# Altium schematic sheet TEMPLATE_Circuit.SchDoc(TEMPLATE_Circuit)
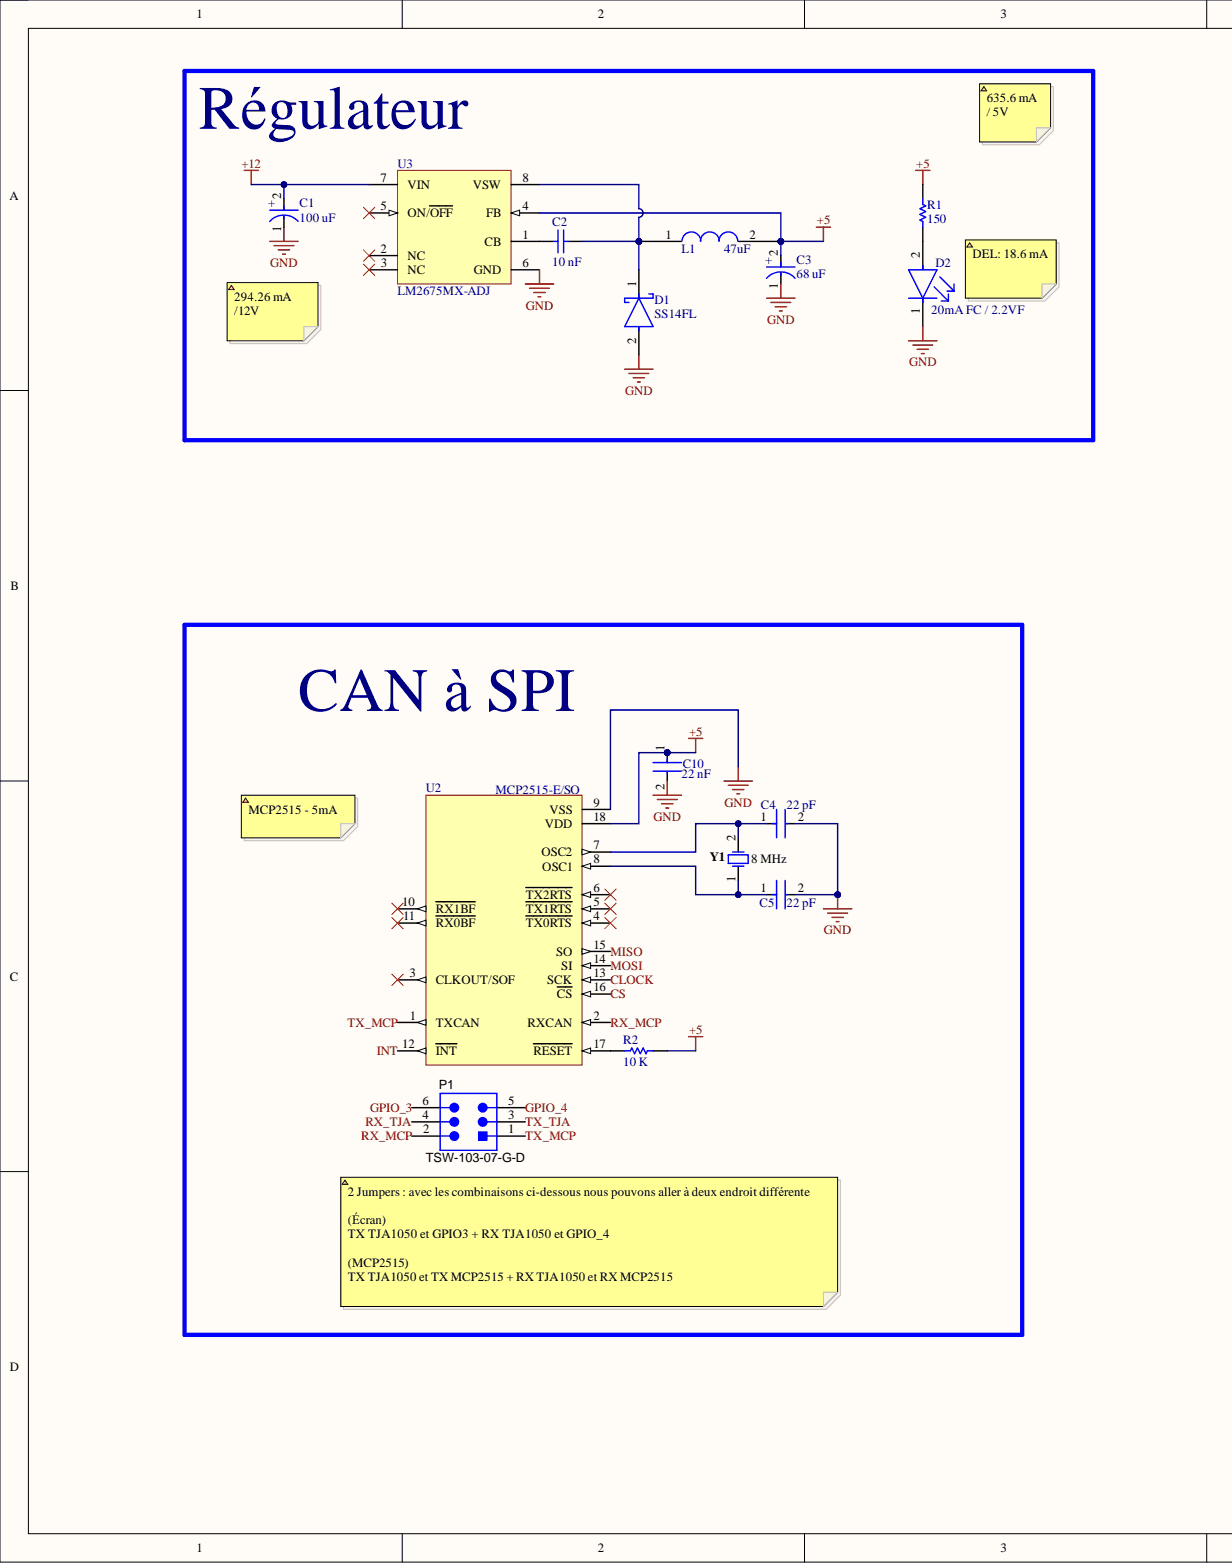
[diagram p0: TEMPLATE_Circuit.SchDoc, left]
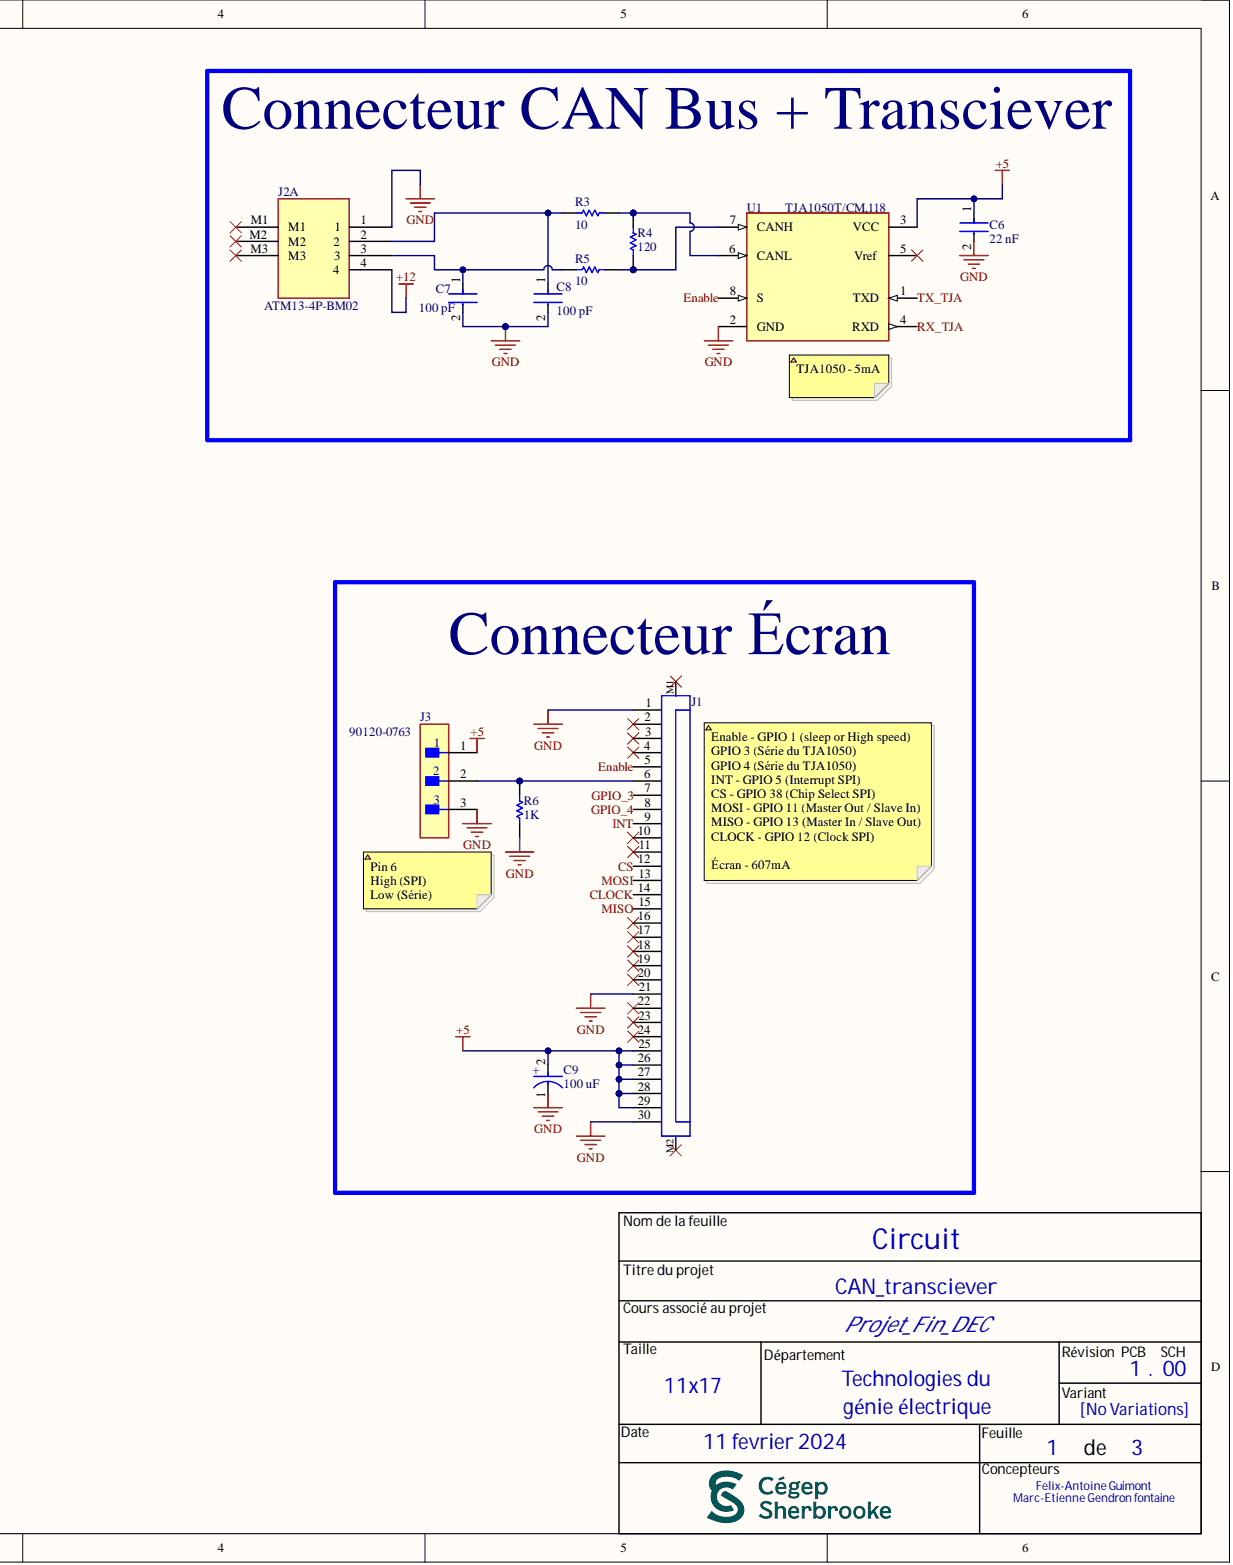
[diagram p1: TEMPLATE_Circuit.SchDoc, right]

## Parts
C1  100 uF  [FP-FKF-MFG]
C2  10 nF  [CAPC1608X90X35ML10T15]
C3  68 uF  [FP-OS-CON-SMT-F12-MFG]
C4  22 pF  [FP-C0805C-DN-MFG]
C5  22 pF  [FP-C0805C-DN-MFG]
C6  22 nF  [FP-C0805C-DN-MFG]
C7  100 pF  [FP-CC0805-0_1-0_7-IPC_A]
C8  100 pF  [FP-CC0805-0_1-0_7-IPC_A]
C9  100 uF  [FP-FKF-MFG]
C10  22 nF  [FP-C0805C-DN-MFG]
D1  SS14FL  [FP-425AD-MFG]
D2  20mA FC / 2.2VF  Forward Current: 20mA  [FP-SML-210-MFG]
J1  68613014422  [68613014422]  (value not drawn)
J2  ATM13-4P-BM02  [ATM134PBM02]  (part A)
J3  90120-0763  [FP-90120-0763-MFG]
L1  47uF  [NPI31W470MTRF]
P1  TSW-103-07-G-D  [SMTC-TSW-103-07-G-D_V]
R1  150  [RESC2013X60X40NL20T25]
R2  10 K  [RESC2013X60X35NL10T20]
R3  10  [RESC2013X50X30NL20T20]
R4  120  [RESC2013X60X40ML20T25]
R5  10  [RESC2013X50X30NL20T20]
R6  1K  [RESC2013X60X35ML10T20]
U1  TJA1050T/CM,118  [FP-SOT96-1-IPC_A]
U2  MCP2515-E/SO  [SOIC-SO18_M]
U3  LM2675MX-ADJ  [D0008A_N]
Y1  8 MHz  [FOX-HC49SDLF_V]

## Nets
+5: C3.2 C6.1 C9.2 C10.1 J1.25 J1.26 J1.27 J1.28 J1.29 J3.1 L1.2 R1.2 R2.2 U1.3(VCC) U2.18(VDD) U3.4(FB)
+12: C1.2 J2.4 U3.7(VIN)
GND: C1.1 C3.1 C4.2 C5.2 C6.2 C7.2 C8.2 C9.1 C10.2 D1.2 D2.1 J1.1 J1.21 J1.30 J2.1 J3.3 R6.1 U1.2(GND) U2.9(VSS) U3.6(GND)
CLOCK: J1.14 U2.13(SCK)
CS: J1.12 U2.16(CS)
Enable: J1.5 U1.8(S)
GPIO_3: J1.7 P1.6
GPIO_4: J1.8 P1.5
INT: J1.9 U2.12(INT)
MISO: J1.15 U2.15(SO)
MOSI: J1.13 U2.14(SI)
RX_MCP: P1.2 U2.2(RXCAN)
RX_TJA: P1.4 U1.4(RXD)
TX_MCP: P1.1 U2.1(TXCAN)
TX_TJA: P1.3 U1.1(TXD)
NetC2_1: C2.1 U3.1(CB)
NetC2_2: C2.2 D1.1 L1.1 U3.8(VSW)
NetC4_1: C4.1 U2.7(OSC2) Y1.2
NetC5_1: C5.1 U2.8(OSC1) Y1.1
NetC7_1: C7.1 J2.3 R5.1
NetC8_1: C8.1 J2.2 R3.1
NetD2_2: D2.2 R1.1
NetJ1_6: J1.6 J3.2 R6.2
NetR2_1: R2.1 U2.17(RESET)
NetR3_2: R3.2 R4.2 U1.6(CANL)
NetR4_1: R4.1 R5.2 U1.7(CANH)

Not connected: J1 2 3 4 10 11 16 17 18 19 20 22 23 24 M1 M2; J2 M1 M2 M3; U1 5(Vref); U2 3(CLKOUT/SOF) 4(TX0RTS) 5(TX1RTS) 6(TX2RTS) 10(RX1BF) 11(RX0BF); U3 2(NC) 3(NC) 5(ON/OFF)

## Library data of the parts
C1 C9 = CMP-2000-06208-3: CAP ALUM 100UF 20% 50V SMD; Dielectric Material: Aluminium; ESR (Equivalent Series Resistance): 340mR; Leakage Current: 3uA; Life (Hours): 2000Hour; Ripple Current: 350mA; Capacitance: 100uF; Case/Package: Radial; Component Kind: Standard; Construction: Cylindrical; Contact Plating: Tin; Diameter: 8mm; Height: 10.2mm; Height - Seated (Max): 0.402inch; Impedance: 340mR; Lead Free: Lead Free; Length: 10.2mm; Library Name: Altium Content Vault; Material: Aluminium; Max Operating Temperature: 105°C; Min Operating Temperature: -55°C; Mount: Surface Mount; Number of Pins: 2; Package Quantity: 1; Packaging: Tape and Reel; Radiation Hardening: No; REACH SVHC: No SVHC; Ripple Current (AC): 350mA; RoHS Compliant: Yes; Series: FK; Termination: SMD/SMT; Tolerance: 20%; Voltage: 50V; Voltage Rating: 50V; Voltage Rating (DC): 50V; Width: 10mm
C2 = CMP-2100-03642-1: Chip Capacitor, 10 nF, +/- 10%, 50 V, -55 to 125 degC, 0603 (1608 Metric), RoHS, Tape and Reel; Manufacturer: Murata; Capacitance: 10nF; Dielectric: X7R; Dielectric Material: Ceramic; Insulation Resistance: 10GR; Voltage: 50V; Case Code (Imperial): 0603; Case Code (Metric): 1608; Case/Package: 0603; Component Kind: Standard; Datasheet URL: http://search.murata.co.jp/Ceramy/image/img/A01X/G101/ENG/GRM188R71H103KA01-01.pdf; Datasheet Version: 42401.0; Depth: 0.8mm; Height: 0.8mm; Lead Free: LeadFree; Length: 1.6mm; Library Name: Altium Content Vault; Manufacturer URL: http://www.murata.com/; Material: Ceramic; Max Operating Temperature: 125degC; Min Operating Temperature: -55degC; Mount: SurfaceMount; Number of Pins: 2; Package Description: 2-Pin Surface Mount Device, Body 1.6 x 0.8 mm; Package Quantity: 1; Package Reference: 0603; Packaging: TapeandReel; REACH SVHC: Unknown; RoHSCompliant: True; Termination: SMD/SMT; Thickness: 0.035inch; Tolerance: 10%; Voltage Rating: 50V; Voltage Rating (DC): 50V; Width: 0.031inch
C3 = CMP-05428-000631-1: Conductive Polymer Aluminum Solid Capacitors (OS-CON) 68uF ±20% 50V RADIAL SMT 10x12.6mm; Dissipation Factor: 12%; ESR (Equivalent Series Resistance): 20mR; Leakage Current: 680uA; Life (Hours): 5000Hour; Polarity: Polar; Ripple Current: 4.3A; Capacitance: 68uF; Case/Package: Radial; Component Kind: Standard; Construction: Cylindrical; Diameter: 10mm; Height: 12.6mm; Height - Seated (Max): 0.5inch; Lead Free: Lead Free; Length: 12.6mm; Library Name: Altium Content Vault; Max Operating Temperature: 105°C; Min Operating Temperature: -55°C; Mount: Surface Mount; Number of Pins: 12; Package Quantity: 400; Packaging: Tape and Reel; REACH SVHC: No SVHC; Ripple Current (AC): 4.3A; RoHS Compliant: Yes; Series: OS-CON™, SVPF; Termination: SMD/SMT; Tolerance: 20%; Voltage: 50V; Voltage Rating: 50V; Voltage Rating (DC): 50V
C4 C5 = CMP-2007-00048-2: Capacitor; Ceramic; Cap; 22.0pF; Tol 5%; SMT; Vol-Rtg 50V; C0G; Tape and Reel; Manufacturer: KEMET; Manufacturer Part Number: C0805C220J5GACTU; Temperature Coefficient: 30ppm/°C; Tolerance: 0.05; Automotive: No; Capacitance: 22 pF; Category: Cap; Component Kind: Standard; ComponentLink2Description: Datasheet; ComponentLink2URL: https://content.kemet.com/datasheets/KEM_C1003_C0G_SMD.pdf; Device Class L1: Passive Components; Device Class L2: Capacitors; Device Class L3: Ceramic Capacitors; Digikey Description: Capacitor; Ceramic; Cap; 22.0pF; Tol 5%; SMT; Vol-Rtg 50V; C0G; Tape and Reel; Height: 0.88mm; Ipc Land Pattern Name: CAPC200125X78; Lead Free: Yes; Library Name: Altium Content Vault; Material: Ceramic; Mouser Description: Multilayer Ceramic Capacitors MLCC - SMD/SMT 50volts 22pF C0G 5%; Octopart: https://octopart.com/c0805c220j5gactu-kemet-145470; Package: C0805C-DN; RoHS: TRUE; Temperature: -55°C to +125°C; Temperature Characteristic: C0G; Temperature Range High: +125°C; Temperature Range Low: -55°C; Value: 22 pF; Voltage Rating: 50 V
C6 C10 = CMP-2007-00338-2: CAP CER 0.022UF 50V X7R 0805; Manufacturer: KEMET; Manufacturer Part Number: C0805C223K5RACTU; Capacitance: 22,000 pF; Material: Ceramic; Temperature Coefficient: 30ppm/°C; Tolerance: 0.1; Value: 22,000 pF; Automotive: No; Category: Cap; Component Kind: Standard; ComponentLink2Description: Datasheet; ComponentLink2URL: https://content.kemet.com/datasheets/KEM_C1003_C0G_SMD.pdf; Device Class L1: Passive Components; Device Class L2: Capacitors; Device Class L3: Ceramic Capacitors; Digikey Description: CAP CER 0.022UF 50V X7R 0805; Height: 0.88mm; Ipc Land Pattern Name: CAPC200125X78; Lead Free: Yes; Library Name: Altium Content Vault; Mouser Description: Capacitor; Ceramic; Cap; .022uF; Tol 10%; SMT; Vol-Rtg 50V; X7R; Tape and Reel; Octopart: https://octopart.com/c0805c223k5ractu-kemet-145968; Package: C0805C-DN; RoHS: TRUE; Temperature: -55°C to +125°C; Temperature Characteristic: X7R; Temperature Range High: +125°C; Temperature Range Low: -55°C; Voltage Rating: 50 V
C7 C8 = CMP-03422-001508-1: CAP CER 100PF 50V C0G/NPO 0805; Dielectric: C0G; Dielectric Material: Ceramic; Insulation Resistance: 100GR; Temperature Coefficient: 30ppm/°C; Tolerance: 5%; Capacitance: 100pF; Case Code (Imperial): 0805; Case Code (Metric): 2012; Case/Package: 0805; Component Kind: Standard; Depth: 1.25mm; Height: 0.6mm; Lead Free: Lead Free; Length: 2mm; Library Name: Altium Content Vault; Max Operating Temperature: 125°C; Min Operating Temperature: -55°C; Mount: Surface Mount; Number of Pins: 2; Package Quantity: 1; Packaging: Tape and Reel; Radiation Hardening: No; REACH SVHC: No SVHC; Resistor Type: Ceramic; RoHS Compliant: Yes; Series: CC; Termination: SMD/SMT; Thickness: 0.024inch; Voltage: 50V; Voltage Rating: 50V; Voltage Rating (DC): 50V; Width: 1.25mm
D1 = CMP-07162-000013-1: DIODE SCHOTTKY 40V 1A SOD123F; Manufacturer: ON Semiconductor; Capacitance: 60pF; Max Junction Temp: +125°C; Max Surge Forward Current: 40A; Peak Reverse Current: 30uA; Value: 60pF; Automotive: No; Category: Diode; Component Kind: Standard; ComponentLink2Description: Datasheet; ComponentLink2URL: https://www.onsemi.com/pub/Collateral/SS14FL-D.PDF; Device Class L1: Discrete Semiconductors; Device Class L2: Diodes; Device Class L3: Schottky Diodes; Digikey Description: DIODE SCHOTTKY 40V 1A SOD123F; Forward Current: 1A; Height: 1.08mm; Lead Free: Yes; Library Name: Altium Content Vault; Manufacturer Part Number: SS14FL; Max Repetitive Reverse Voltage: 40V; Mouser Description: SS14FL Series 40 V 1 A Surface Mount Schottky Barrier Rectifier - SOD-123F; Octopart: https://octopart.com/ss14fl-on+semiconductor-84331601; Package: 425AD; Reverse Voltage: 40V; RoHS: TRUE; Temperature: -55°C to +125°C; Temperature Range High: +125°C; Temperature Range Low: -55°C
D2 = CMP-08952-000013-1: LED GREEN CLEAR 0805 SMD; Manufacturer: ROHM; Manufacturer Part Number: SML-210MTT86; Forward Voltage: 2.2V; Led Orientation: Top View; Luminous Intensity: 16mcd; Peak Emmision Wavelength: 573nm; Power Dissipation: 70mW; Reverse Voltage: 4V; Wavelength: 570nm; Automotive: No; Category: Diode; Colour: Green; Component Kind: Standard; ComponentLink2Description: Datasheet; ComponentLink2URL: https://www.mouser.in/ds/2/348/sml-21-313092.pdf; Device Class L1: Optoelectronics; Device Class L2: LEDs; Device Class L3: unset; Digikey Description: LED GREEN CLEAR 0805 SMD; Height: 0.90mm; Ipc Land Pattern Name: DIOCP200125X25; Lead Free: Yes; Library Name: Altium Content Vault; Mouser Description: Standard LEDs - SMD GREEN SMD TRANS LENS; Octopart: https://octopart.com/sml-210mtt86-rohm-550711; Package: SML-210; RoHS: TRUE; Temperature: -30°C to +85°C; Temperature Range High: +85°C; Temperature Range Low: -30°C
J1 = CMP-1502-01467-2: NexarPartId: 22802282; Actuator Material: Polyphenylene; Contact Resistance: 20mΩ; Current Rating: 1A; Gender: Receptacle; Insulation Resistance: 100MΩ; Number of Contacts: 30; Operating Supply Voltage: 125V; Pitch: 1mm; Component Kind: Standard; Contact Material: Bronze, Tin; Contact Plating: Tin; Flammability Rating: UL94 V-0; Housing Material: Polyphenylene; Lead Pitch: 1mm; Length: 37mm; Library Name: Altium Content Vault; Max Operating Temperature: 85°C; Min Operating Temperature: -25°C; Mount: Surface Mount; Number of Pins: 30; Number of Positions: 30; Number of Rows: 1; Orientation: Horizontal; Packaging: Tape and Reel; REACH SVHC: Unknown; RoHS: Compliant; Termination: Solder; Voltage Rating: 125V; Width: 3mm
J3 = CMP-04776-000084-1: CONN HEADER VERT 3POS 2.54MM; Manufacturer: Molex; Conn Gender: Male; Conn Orientation: Vertical; Current Rating: 3A; Number Of Contacts: 3; Voltage Rating: 350V; Automotive: No; Category: Conn; Component Kind: Standard; ComponentLink2Description: Datasheet; ComponentLink2URL: https://www.molex.com/webdocs/datasheets/pdf/en-us/0901200763_PCB_HEADERS.pdf; Contact Material: Gold,Nickel; Device Class L1: Connectors; Device Class L2: Headers and Wire Housings; Device Class L3: unset; Digikey Description: CONN HEADER VERT 3POS 2.54MM; Footprint Url: https://www.molex.com/pdm_docs/sd/901200763_sd.pdf; Height: 5.8mm; Lead Free: Yes; Library Name: Altium Content Vault; Manufacturer Part Number: 90120-0763; Mouser Description: Board-To-Board Connector, C-Grid III 90120 Series, Through Hole, Header, 3, 2.54 mm; Number Of Rows: 1; Octopart: https://octopart.com/90120-0763-molex-655433; Package: 90120-0763; Pitch: 2.54mm; RoHS: TRUE; Temperature: -55°C to +125°C; Temperature Range High: +125°C; Temperature Range Low: -55°C
L1 = TEMPLATE_Circuit: Component Kind: Standard; Library Name: NPI31W470MTRF.SchLib
P1 = CMP-2000-07329-1: Through Hole Header, Pitch 2.54 mm, 2 x 3 Position, Height 8.58 mm, Tail Length 2.54 mm, -55 to 125 degC, RoHS, Bulk; Manufacturer: Samtec-Inc.; Connector Type: Header,Unshrouded; Number of Positions: 6; Number of Positions Loaded: All; Pitch: 0.100"(2.54mm); Category: Connectors,Interconnects; Color: Black; Component Kind: Standard; Contact Finish: Gold; Contact Finish Thickness: 10µin(0.25µm); Contact Mating Length: 0.230"(5.84mm); Contact Type: MalePin; Datasheet URL: http://suddendocs.samtec.com/catalog_english/tsw_th.pdf; Datasheet Version: 12/2015; Family: RectangularConnectors-Headers,MalePins; Fastening Type: -; Features: -; Library Name: Altium Content Vault; Manufacturer URL: http://www.samtec.com/; Mounting Technology: ThroughHole; Mounting Type: ThroughHole; Number of Rows: 2; Package Description: Through Hole Header, Pitch 2.54 mm, 2 x 3 Position, Height 8.58 mm, Tail Length 2.54 mm; Package Reference: TSW-103-07-G-D; Package URL: http://suddendocs.samtec.com/prints/tsw-xxx-xx-xxx-x-xx-xxx-mkt.pdf; Packaging: Bulk; Row Spacing: 0.100"(2.54mm); Series: TSW; Termination: Solder
R1 = CMP-2001-00596-1: Manufacturer: Panasonic; Part Number: ERA6AEB151V; Component Kind: Standard; Library Name: Altium Content Vault
R2 = CMP-1659-00013-4: Chip Resistor, 10 KOhm, +/- 1%, 0.125 W, -55 to 155 degC, 0805 (2012 Metric), RoHS, Tape and Reel; Manufacturer: Yageo; Voltage Rating: 150 V; Component Kind: Standard; ComponentLink1Description: Manufacturer URL; ComponentLink1URL: http://www.yageo.com/; ComponentLink2Description: Datasheet; ComponentLink2URL: http://www.yageo.com/documents/recent/PYu-RC_Group_51_RoHS_L_04.pdf; DatasheetVersion: Rev. 4, 02/2016; Height: 0.5 mm; Length: 2 mm; Library Name: Altium Content Vault; Max Operating Temperature: 155 degC; Min Operating Temperature: -55 degC; Mount: Surface Mount; Mounting Technology: Surface Mount; Package Quantity: 5000; PackageDescription: 2-Pin Surface Mounted Device, Body 2 x 1.25 mm; PackageReference: 0805; Packaging: Tape and Reel; Pins: 2; REACH SVHC: No SVHC; RoHSCompliant: true
R3 R5 = CMP-2001-04915-1: Manufacturer: Vishay Dale; Part Number: CRCW080510R0FKEA; Component Kind: Standard; Library Name: Altium Content Vault
R4 = CMP-2001-00588-1: Manufacturer: Panasonic; Part Number: ERA6AEB121V; Component Kind: Standard; Library Name: Altium Content Vault
R6 = CMP-2100-03671-1: Chip Resistor, 1 KOhm, +/- 1%, 125 mW, -55 to 155 degC, 0805 (2012 Metric), RoHS, Tape and Reel; Manufacturer: Yageo; Max Power Dissipation: 125mW; Resistance: 1KOhm; Temperature Coefficient: 100ppm/degreesC; Voltage Rating: 150V; Case Code (Imperial): 0805; Case Code (Metric): 2012; Case/Package: 0805; Component Kind: Standard; Composition: ThickFilm; Datasheet URL: http://www.yageo.com/NewPortal/yageodocoutput?fileName=/pdf/R-Chip/PYu-RC_Group_51_RoHS_L_5.pdf; Datasheet Version: Rev. 5, 10/2016; Depth: 1.25mm; Height: 0.024inch; Lead Free: LeadFree; Length: 0.079inch; Library Name: Altium Content Vault; Manufacturer URL: http://www.yageo.com/; Max Operating Temperature: 155degC; Military Standard: Not; Min Operating Temperature: -55degC; Number of Pins: 2; Number of Terminations: 2; Package Description: 2-Pin Surface Mounted Device, Body 2 x 1.25 mm; Package Quantity: 1; Package Reference: 0805; Packaging: TapeandReel; Power Rating: 125mW; REACH SVHC: Unknown; RoHSCompliant: true; Termination: SMD/SMT; Tolerance: 1%; Voltage Rating (DC): 150V; Width: 0.049inch
U1 = CMP-2000-04923-2: IC TRANSCEIVER HALF 1/1 8SO; Data Rate: 1Mbps; Interface: CAN; Max Supply Current: 10mA; Max Supply Voltage: 5.25V; Min Supply Voltage: 4.75V; Number of Receive Buffers: 1; Receiver Hysteresis: 70mV; Simplex/Duplex: Half Duplex; Case/Package: SO; Component Kind: Standard; Contact Plating: Gold; Lead Free: Lead Free; Library Name: Altium Content Vault; Max Operating Temperature: 150°C; Min Operating Temperature: -40°C; Mount: Surface Mount; Nominal Supply Current: 10mA; Number of Pins: 8; Number of Transceivers: 1; Number of Transmit Buffers: 1; Package Quantity: 2500; Packaging: Tape and Reel; Radiation Hardening: No; REACH SVHC: No SVHC
U2 = CMP-0186-00035-2: Stand-Alone CAN Controller With SPI Interface, 18-Pin SOIC, Extended Temperature; Code_JEDEC: MS-013; Component Kind: Standard; ComponentLink1Description: Manufacturer URL; ComponentLink1URL: http://www.microchip.com/; ComponentLink2Description: Datasheet; ComponentLink2URL: http://ww1.microchip.com/downloads/en/DeviceDoc/21801f.pdf; ComponentLink3Description: Package Specification; ComponentLink3URL: http://www.microchip.com/stellent/groups/techpub_sg/documents/packagingspec/en012702.pdf; DatasheetVersion: revF, Oct-2010; Library Name: Altium Content Vault; PackageDescription: 18-Lead Plastic Small Outline (SO) - Wide 7.50mm Body [SOIC]; PackageReference: SOIC-SO18; PackageVersion: revBB, Aug-2009; RevisionNote: URLs added. DatasheetVersion updated.
U3 = CMP-0069-00674-3: SIMPLE SWITCHER® Power Converter High Efficiency 1A Step-Down Voltage Regulator, 8-pin Narrow SOIC; Manufacturer: Texas Instruments; PackageTopMarking: NSZXTT*2675*MADJ; PowerWise: N; Code_IPC: SOIC127P600-8; Code_JEDEC: MS-012-AA; Component Kind: Standard; ComponentLink1Description: Datasheet URL; ComponentLink1URL: http://www.ti.com/general/docs/lit/getliterature.tsp?genericPartNumber=LM2675&fileType=pdf; CompType: Switcher; Library Name: Altium Content Vault; PackageDescription: SOIC Narrow, 4.9 x 3.9 x 1.45mm, 8 Lead, 1.27mm Pitch; PackageReference: D0008A; PinCount: 8; RoHS: N
Y1 = CMP-2100-03639-1: Resistance Weld Crystal, 8 MHz, +/- 30 ppm, -20 to 70 degC, 2-Pin SMD, RoHS, Tape and Reel; Manufacturer: FOX Electronics; ESR (Equivalent Series Resistance): 80Ohm; Frequency: 8MHz; Frequency Stability: 50ppm; Frequency Tolerance: 30ppm; Load Capacitance: 20pF; Case/Package: SMD/SMT; Component Kind: Standard; Datasheet URL: http://www.foxonline.com/pdfs/hc49sdlf.pdf; Datasheet Version: 42064.0; Depth: 5mm; Height: 4.5mm; Lead Free: LeadFree; Length: 11.7mm; Library Name: Altium Content Vault; Manufacturer URL: http://www.foxonline.com/; Max Operating Temperature: 70degC; Min Operating Temperature: -20degC; Mount: SurfaceMount; Number of Pins: 2; Package Description: 2-Pin Surface Mount Device, Body 5 x 11.7 mm; Package Quantity: 1000; Package Reference: HC49SDLF; Packaging: TapeandReel; Radiation Hardening: No; REACH SVHC: NoSVHC; RoHSCompliant: True; Series Resistance: 80Ohm; Termination: SMD/SMT; Tolerance: 50ppm; Width: 5mm
J2 = ATM13-4P-BM02: Component Kind: Standard; Library Name: PCB_MCP_Final.SCHLIB
